# Altium schematic sheet Module_4x5.SchDoc(Module_4x5)
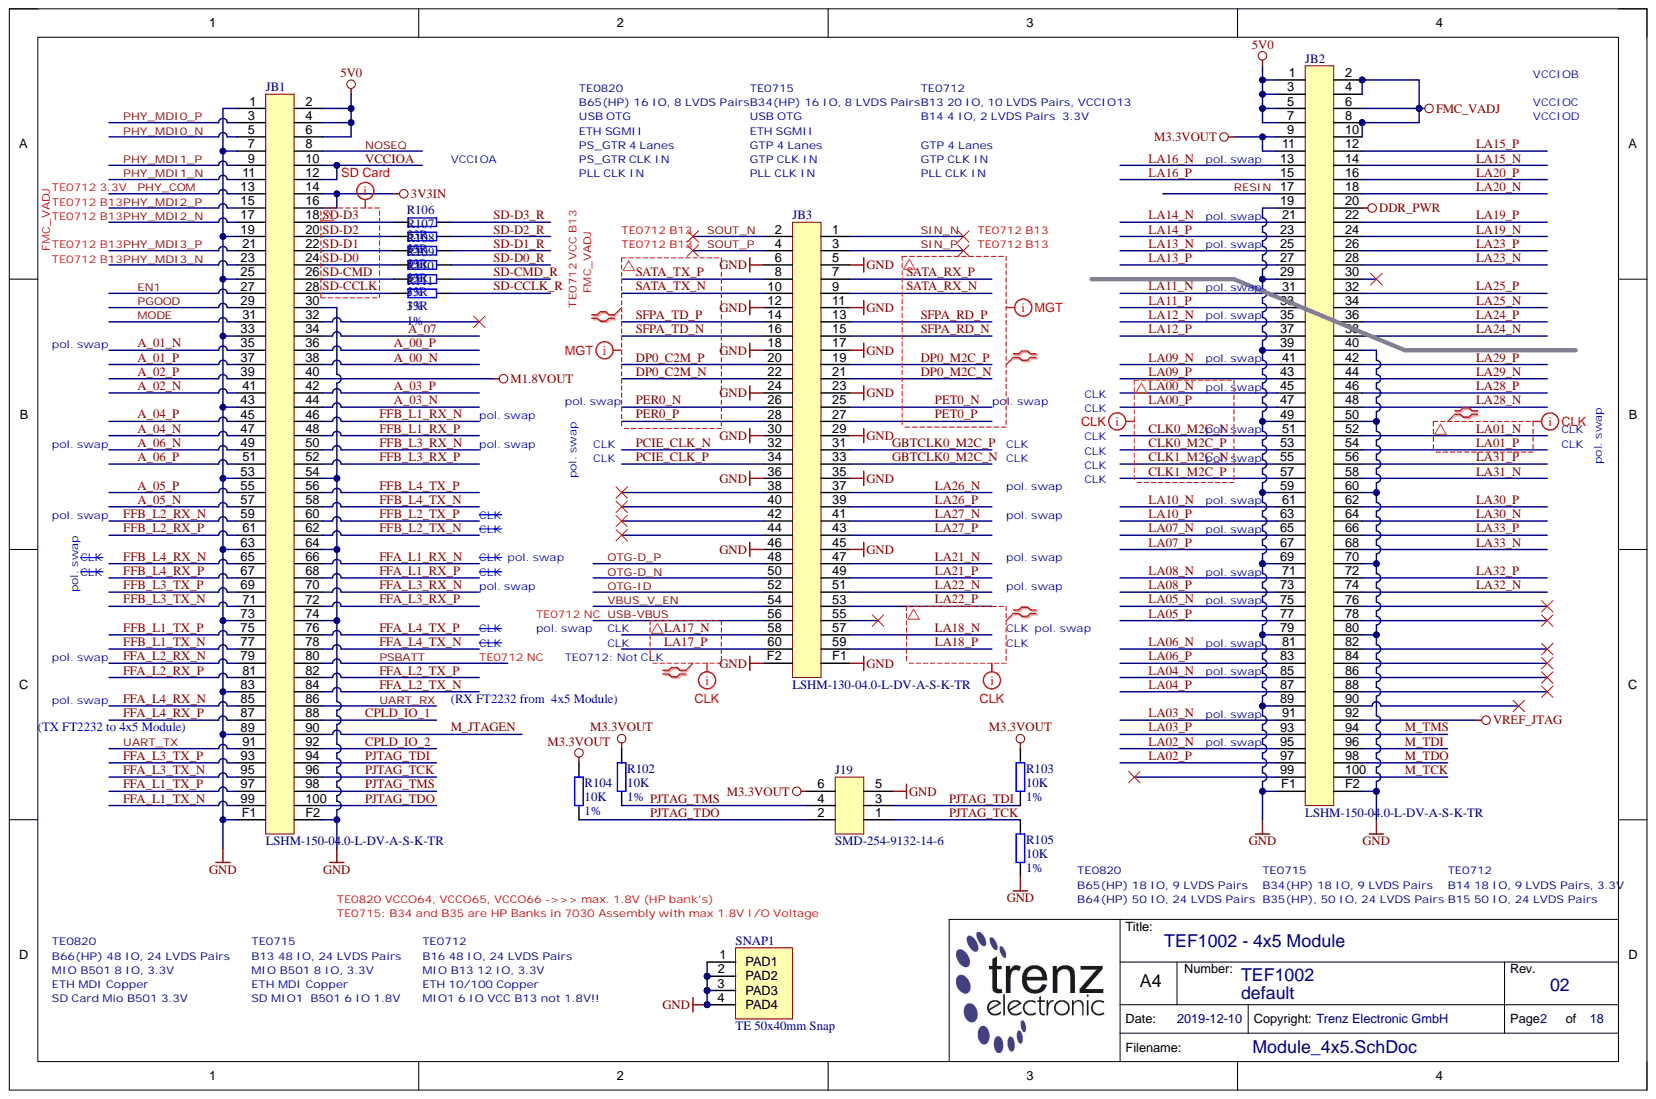
[diagram p0: Module_4x5.SchDoc]

## Parts
J19  SMD-254-9132-14-6  [SMD-254-9132-14-6]
JB1  LSHM-150-04.0-L-DV-A-S-K-TR  [LSHM-150-XXX-X-DV-A-X]
JB2  LSHM-150-04.0-L-DV-A-S-K-TR  [LSHM-150-XXX-X-DV-A-X]
JB3  LSHM-130-04.0-L-DV-A-S-K-TR  [LSHM-130-04.0-x-DV-A-S-K-TR]
R102  10K  Tolerance: 1%  [RESC1005X40N]
R103  10K  Tolerance: 1%  [RESC1005X40N]
R104  10K  Tolerance: 1%  [RESC1005X40N]
R105  10K  Tolerance: 1%  [RESC1005X40N]
R106  33R  [RESC0603X26N]
R107  33R  Tolerance: 1%  [RESC0603X26N]
R108  33R  Tolerance: 1%  [RESC0603X26N]
R109  33R  Tolerance: 1%  [RESC0603X26N]
R110  33R  Tolerance: 1%  [RESC0603X26N]
R111  33R  Tolerance: 1%  [RESC0603X26N]
SNAP1  TE 50x40mm Snap  [TE_50x40mm_Snap]  (no BOM)

## Nets
3V3IN: JB1.14 JB1.16
5V0: JB1.2 JB1.4 JB1.6 JB2.1 JB2.3 JB2.5 JB2.7
DDR_PWR: JB2.20
FMC_VADJ: JB2.2 JB2.4 JB2.6 JB2.8 JB2.10
GND: J19.5 JB1.1 JB1.7 JB1.19 JB1.25 JB1.30 JB1.33 JB1.43 JB1.53 JB1.54 JB1.63 JB1.64 JB1.73 JB1.74 JB1.83 JB1.89 JB1.F1 JB1.F2 JB2.19 JB2.29 JB2.39 JB2.40 JB2.49 JB2.50 JB2.59 JB2.60 JB2.69 JB2.70 JB2.79 JB2.80 JB2.89 JB2.F1 JB2.F2 JB3.5 JB3.6 JB3.11 JB3.12 JB3.17 JB3.18 JB3.23 JB3.24 JB3.29 JB3.30 JB3.35 JB3.36 JB3.45 JB3.46 JB3.F1 JB3.F2 R105.1 SNAP1.1(PAD1) SNAP1.2(PAD2) SNAP1.3(PAD3) SNAP1.4(PAD4)
M1.8VOUT: JB1.40
M3.3VOUT: J19.6 JB2.9 JB2.11 R102.2 R103.1 R104.2
VREF_JTAG: JB2.92
A_00_N: JB1.38
A_00_P: JB1.36
A_01_N: JB1.35
A_01_P: JB1.37
A_02_N: JB1.41
A_02_P: JB1.39
A_03_N: JB1.44
A_03_P: JB1.42
A_04_N: JB1.47
A_04_P: JB1.45
A_05_N: JB1.57
A_05_P: JB1.55
A_06_N: JB1.49
A_06_P: JB1.51
A_07: JB1.34
CLK0_M2C_N: JB2.51
CLK0_M2C_P: JB2.53
CLK1_M2C_N: JB2.55
CLK1_M2C_P: JB2.57
CPLD_IO_1: JB1.88
CPLD_IO_2: JB1.92
DP0_C2M_N: JB3.22
DP0_C2M_P: JB3.20
DP0_M2C_N: JB3.21
DP0_M2C_P: JB3.19
EN1: JB1.27
FFA_L1_RX_N: JB1.66
FFA_L1_RX_P: JB1.68
FFA_L1_TX_N: JB1.99
FFA_L1_TX_P: JB1.97
FFA_L2_RX_N: JB1.79
FFA_L2_RX_P: JB1.81
FFA_L2_TX_N: JB1.84
FFA_L2_TX_P: JB1.82
FFA_L3_RX_N: JB1.70
FFA_L3_RX_P: JB1.72
FFA_L3_TX_N: JB1.95
FFA_L3_TX_P: JB1.93
FFA_L4_RX_N: JB1.85
FFA_L4_RX_P: JB1.87
FFA_L4_TX_N: JB1.78
FFA_L4_TX_P: JB1.76
FFB_L1_RX_N: JB1.46
FFB_L1_RX_P: JB1.48
FFB_L1_TX_N: JB1.77
FFB_L1_TX_P: JB1.75
FFB_L2_RX_N: JB1.59
FFB_L2_RX_P: JB1.61
FFB_L2_TX_N: JB1.62
FFB_L2_TX_P: JB1.60
FFB_L3_RX_N: JB1.50
FFB_L3_RX_P: JB1.52
FFB_L3_TX_N: JB1.71
FFB_L3_TX_P: JB1.69
FFB_L4_RX_N: JB1.65
FFB_L4_RX_P: JB1.67
FFB_L4_TX_N: JB1.58
FFB_L4_TX_P: JB1.56
GBTCLK0_M2C_N: JB3.33
GBTCLK0_M2C_P: JB3.31
LA00_N: JB2.45
LA00_P: JB2.47
LA01_N: JB2.52
LA01_P: JB2.54
LA02_N: JB2.95
LA02_P: JB2.97
LA03_N: JB2.91
LA03_P: JB2.93
LA04_N: JB2.85
LA04_P: JB2.87
LA05_N: JB2.75
LA05_P: JB2.77
LA06_N: JB2.81
LA06_P: JB2.83
LA07_N: JB2.65
LA07_P: JB2.67
LA08_N: JB2.71
LA08_P: JB2.73
LA09_N: JB2.41
LA09_P: JB2.43
LA10_N: JB2.61
LA10_P: JB2.63
LA11_N: JB2.31
LA11_P: JB2.33
LA12_N: JB2.35
LA12_P: JB2.37
LA13_N: JB2.25
LA13_P: JB2.27
LA14_N: JB2.21
LA14_P: JB2.23
LA15_N: JB2.14
LA15_P: JB2.12
LA16_N: JB2.13
LA16_P: JB2.15
LA17_N: JB3.58
LA17_P: JB3.60
LA18_N: JB3.57
LA18_P: JB3.59
LA19_N: JB2.24
LA19_P: JB2.22
LA20_N: JB2.18
LA20_P: JB2.16
LA21_N: JB3.47
LA21_P: JB3.49
LA22_N: JB3.51
LA22_P: JB3.53
LA23_N: JB2.28
LA23_P: JB2.26
LA24_N: JB2.38
LA24_P: JB2.36
LA25_N: JB2.34
LA25_P: JB2.32
LA26_N: JB3.37
LA26_P: JB3.39
LA27_N: JB3.41
LA27_P: JB3.43
LA28_N: JB2.48
LA28_P: JB2.46
LA29_N: JB2.44
LA29_P: JB2.42
LA30_N: JB2.64
LA30_P: JB2.62
LA31_N: JB2.58
LA31_P: JB2.56
LA32_N: JB2.74
LA32_P: JB2.72
LA33_N: JB2.68
LA33_P: JB2.66
M_JTAGEN: JB1.90
M_TCK: JB2.100
M_TDI: JB2.96
M_TDO: JB2.98
M_TMS: JB2.94
MODE: JB1.31
NOSEQ: JB1.8
OTG-D_N: JB3.50
OTG-D_P: JB3.48
OTG-ID: JB3.52
PCIE_CLK_N: JB3.32
PCIE_CLK_P: JB3.34
PER0_N: JB3.26
PER0_P: JB3.28
PET0_N: JB3.25
PET0_P: JB3.27
PGOOD: JB1.29
PHY_COM: JB1.13
PHY_MDI0_N: JB1.5
PHY_MDI0_P: JB1.3
PHY_MDI1_N: JB1.11
PHY_MDI1_P: JB1.9
PHY_MDI2_N: JB1.17
PHY_MDI2_P: JB1.15
PHY_MDI3_N: JB1.23
PHY_MDI3_P: JB1.21
PJTAG_TCK: J19.1 JB1.96 R105.2
PJTAG_TDI: J19.3 JB1.94 R103.2
PJTAG_TDO: J19.2 JB1.100 R104.1
PJTAG_TMS: J19.4 JB1.98 R102.1
PSBATT: JB1.80
RESIN: JB2.17
SATA_RX_N: JB3.9
SATA_RX_P: JB3.7
SATA_TX_N: JB3.10
SATA_TX_P: JB3.8
SD-CCLK: JB1.28 R111.2
SD-CCLK_R: R111.1
SD-CMD: JB1.26 R110.2
SD-CMD_R: R110.1
SD-D0: JB1.24 R109.2
SD-D0_R: R109.1
SD-D1: JB1.22 R108.2
SD-D1_R: R108.1
SD-D2: JB1.20 R107.2
SD-D2_R: R107.1
SD-D3: JB1.18 R106.2
SD-D3_R: R106.1
SFPA_RD_N: JB3.15
SFPA_RD_P: JB3.13
SFPA_TD_N: JB3.16
SFPA_TD_P: JB3.14
SIN_N: JB3.1
SIN_P: JB3.3
SOUT_N: JB3.2
SOUT_P: JB3.4
UART_RX: JB1.86
UART_TX: JB1.91
USB-VBUS: JB3.56
VBUS_V_EN: JB3.54
VCCIOA: JB1.10 JB1.12

Not connected: JB1 32; JB2 30 76 78 82 84 86 88 90 99; JB3 38 40 42 44 55

## Library data of the parts
J19 = 22742: Stiftleiste gerade 2-reihig, 6pol. SMD; Database Table Name: CON_HEADER; H-ART: 9132-14-006-00-0-00; Mixed: SMT; Trenz-Stock: Yes; AN: 22742; Component Kind: Standard; Library Name: TE.DbLib; HelpURL: B:\AD\adlib\datasheet\9532_9132
JB1 JB2 = 23838: Samtec Razor Beam REF-189016-02, LF-04.0, 100 Pins, für alle 4x5 SoMs; Database Table Name: CON_B2B; Mixed: SMT; Preferred: Yes; AN: 23838; Component Kind: Standard; H-ART: REF-189016-02; Library Name: TE.DbLib; Trenz-Stock: Yes; HelpURL: B:\AD\adlib\datasheet\LSHM-1XX-XX-XXX-DV-A
JB3 = 24903: Samtec Razor Beam REF-189017-02, LF-04.0, 60 Pins, für alle 4x5 SoMs; Database Table Name: CON_B2B; Mixed: SMT; Trenz-Stock: Yes; AN: 24903; Component Kind: Standard; H-ART: REF-189017-02; Library Name: TE.DbLib; HelpURL: B:\AD\adlib\datasheet\LSHM-1XX-XX-XXX-DV-A
R102-R105 = 22750: WID SMD 10K 1% 0,063W BF 1005 (0402); Database Table Name: R_1005_0402; H-ART: RC0402FR-0710KL; Mixed: SMT; Preferred: Yes; AN: 22750; Case: 1005 (0402); Component Kind: Standard; Library Name: TE.DbLib; Technology: TK100; Value: 10K
R106-R111 = 25021: WID SMD 33R 1% 0,05W  BF 0603 (0201); Database Table Name: R_0603_0201; H-ART: RC0201FR-0733RL; Mixed: SMT; Preferred: Yes; Value: 33; AN: 25021; Case: 0603 (0201); Component Kind: Standard; Library Name: TE.DbLib; Technology: TK100; HelpURL: B:\AD\adlib\datasheet\R0201
  R106: Tolerance: 1%
SNAP1 = 25288: TE 50x40mm Baseboard Snap; Database Table Name: BLOCKS; Mixed: SMT; AN: 25288; Library Name: TE.DbLib
